# Altium schematic sheet Sheet4.SchDoc
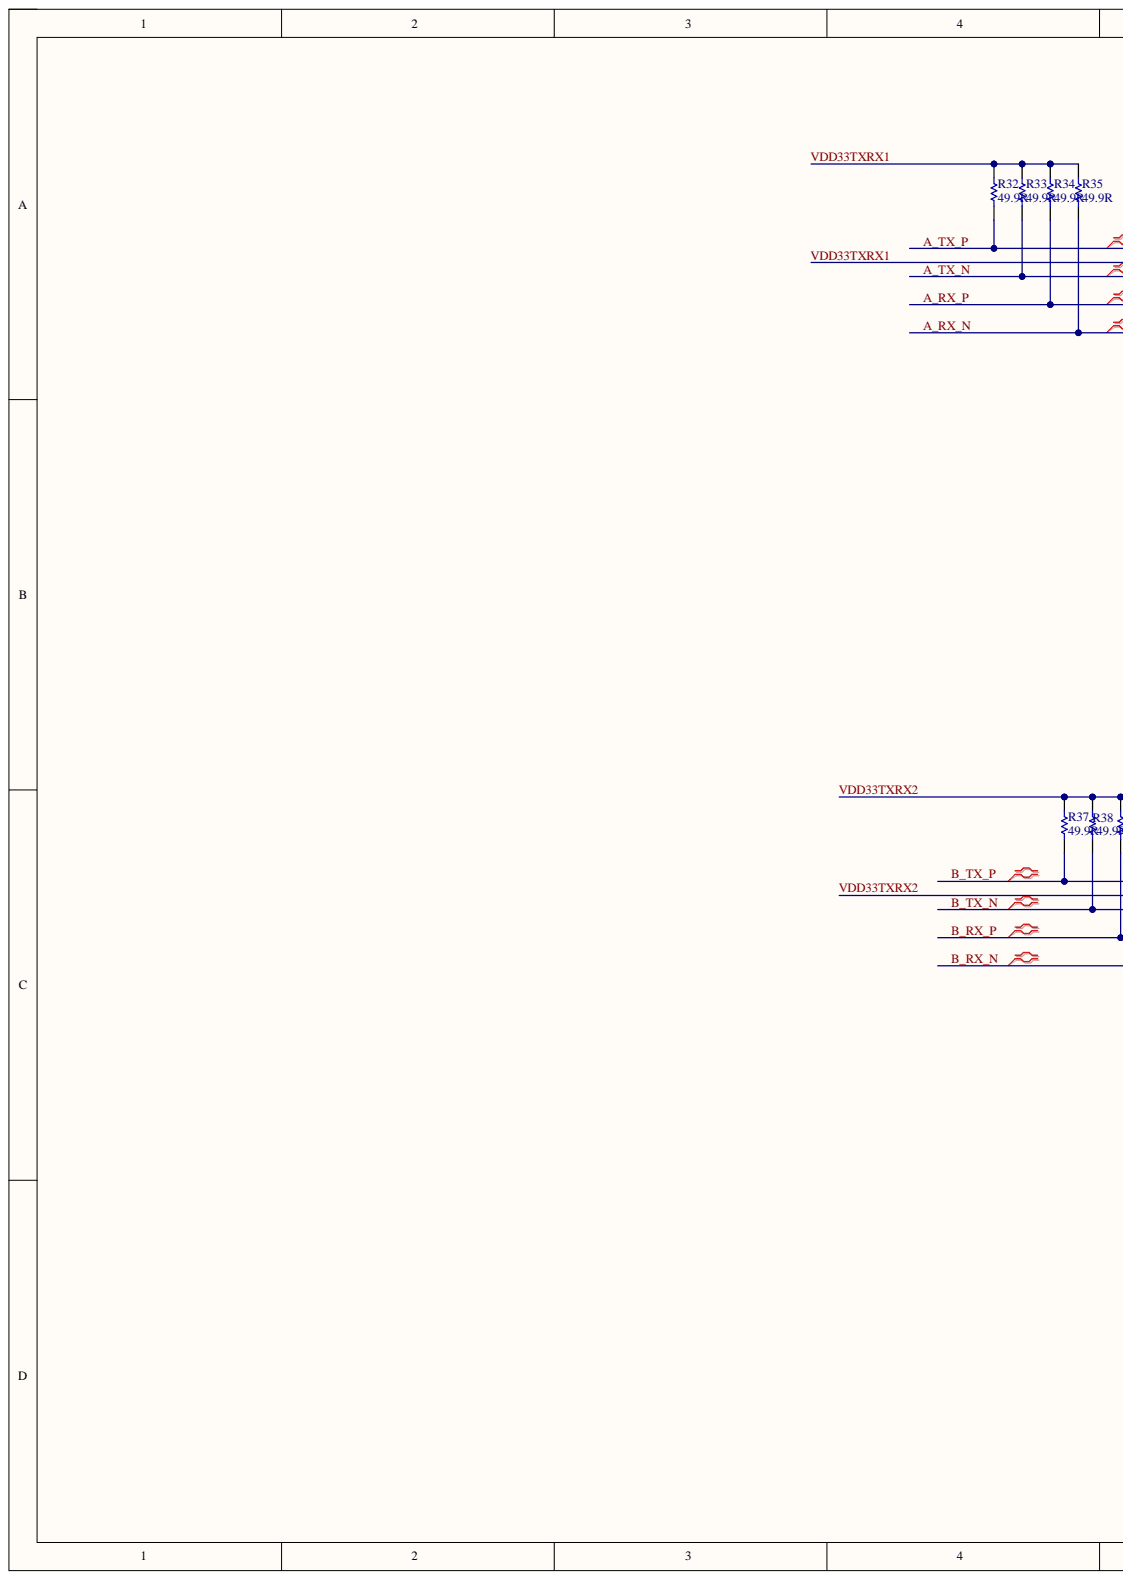
[diagram p0: Sheet4.SchDoc, left]
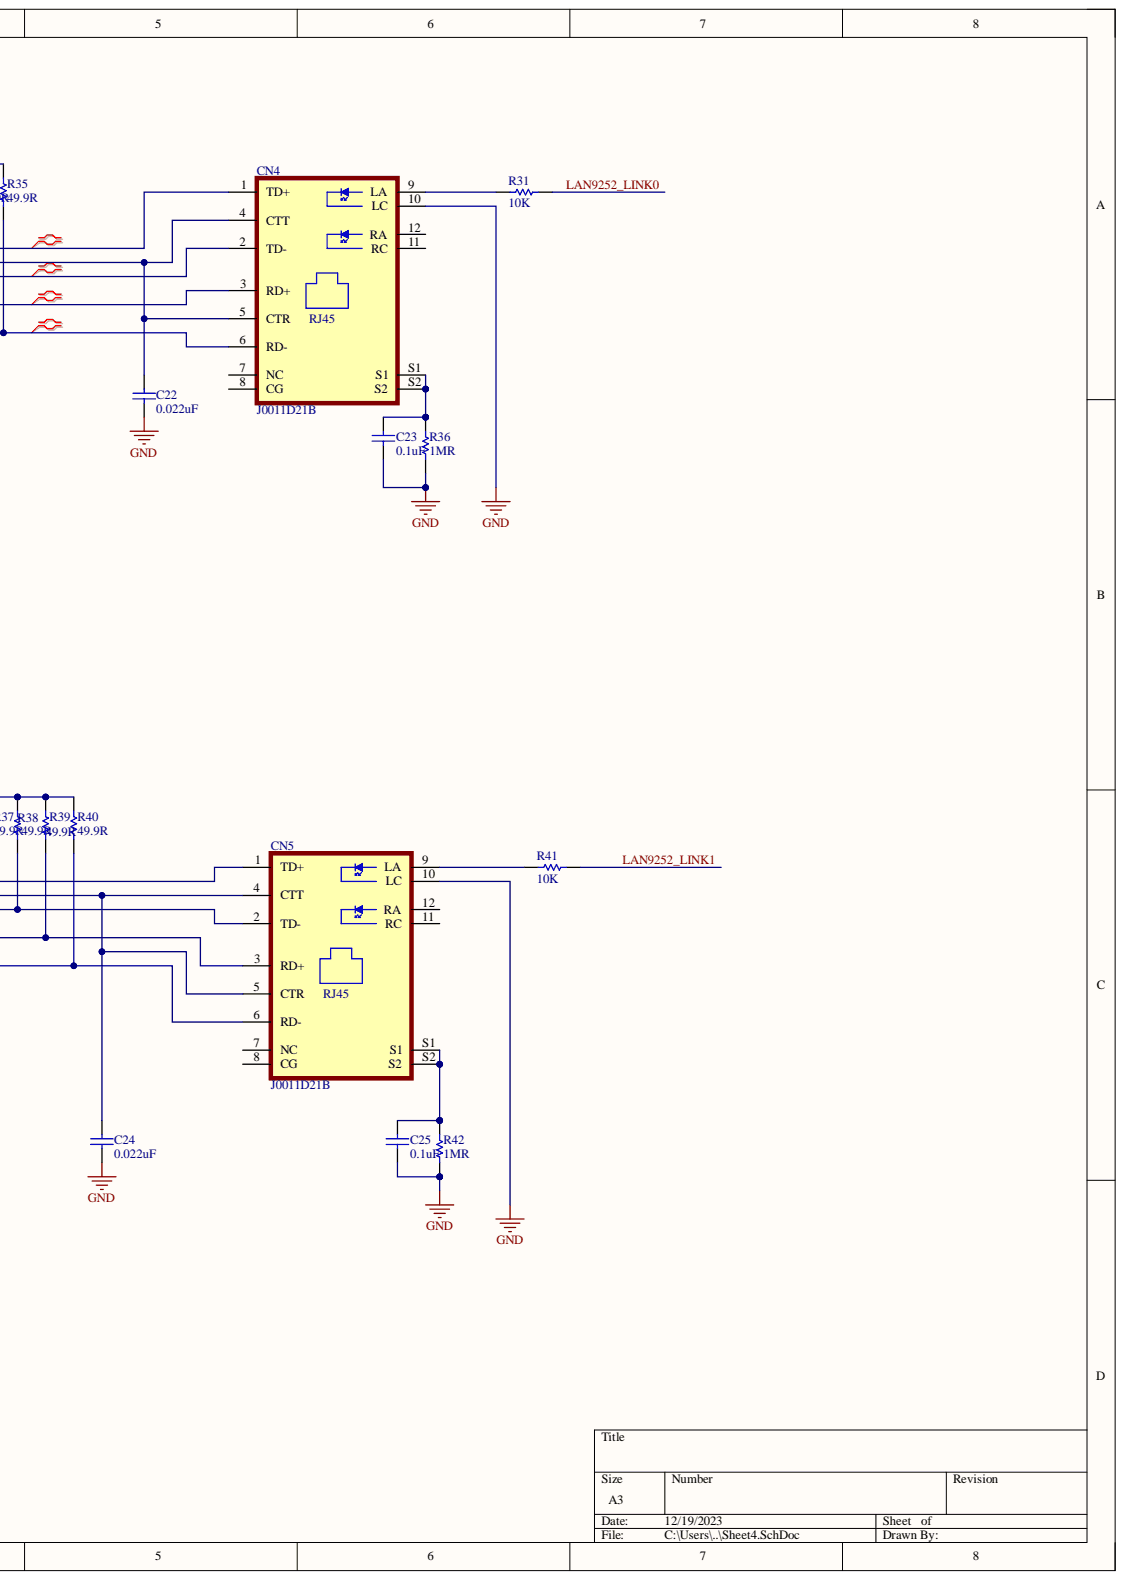
[diagram p1: Sheet4.SchDoc, right]

## Parts
C22  value 0.022uF  [Capacitor_1608]  (hidden comment: Condenser_1608)
C23  value 0.1uF  [Capacitor_2012]  (hidden comment: Condenser_2012)
C24  value 0.022uF  [Capacitor_1608]  (hidden comment: Condenser_1608)
C25  value 0.1uF  [Capacitor_2012]  (hidden comment: Condenser_2012)
CN4  J0011D21B  [J0011D21B]
CN5  J0011D21B  [J0011D21B]
R31  value 10K  [Resistance_1608]  (hidden comment: Resista_1608)
R32  value 49.9R  [Resistance_1608]  (hidden comment: Resista_1608)
R33  value 49.9R  [Resistance_1608]  (hidden comment: Resista_1608)
R34  value 49.9R  [Resistance_1608]  (hidden comment: Resista_1608)
R35  value 49.9R  [Resistance_1608]  (hidden comment: Resista_1608)
R36  value 1MR  [Resistance_2012]  (hidden comment: Resista_2012)
R37  value 49.9R  [Resistance_1608]  (hidden comment: Resista_1608)
R38  value 49.9R  [Resistance_1608]  (hidden comment: Resista_1608)
R39  value 49.9R  [Resistance_1608]  (hidden comment: Resista_1608)
R40  value 49.9R  [Resistance_1608]  (hidden comment: Resista_1608)
R41  value 10K  [Resistance_1608]  (hidden comment: Resista_1608)
R42  value 1MR  [Resistance_2012]  (hidden comment: Resista_2012)

## Nets
GND: C22.1 C23.1 C24.1 C25.1 CN4.10(LC) CN5.10(LC) R36.1 R42.1
A_RX_N: CN4.6(RD-) R35.2
A_RX_P: CN4.3(RD+) R34.2
A_TX_N: CN4.2(TD-) R33.2
A_TX_P: CN4.1(TD+) R32.2
B_RX_N: CN5.6(RD-) R40.2
B_RX_P: CN5.3(RD+) R39.2
B_TX_N: CN5.2(TD-) R38.2
B_TX_P: CN5.1(TD+) R37.2
LAN9252_LINK0: R31.2
LAN9252_LINK1: R41.2
VDD33TXRX1: C22.2 CN4.4(CTT) CN4.5(CTR) R32.1 R33.1 R34.1 R35.1
VDD33TXRX2: C24.2 CN5.4(CTT) CN5.5(CTR) R37.1 R38.1 R39.1 R40.1
NetC23_2: C23.2 CN4.S1 CN4.S2 R36.2
NetC25_2: C25.2 CN5.S1 CN5.S2 R42.2
NetCN4_9: CN4.9(LA) R31.1
NetCN5_9: CN5.9(LA) R41.1

Not connected: CN4 7(NC) 8(CG) 11(RC) 12(RA); CN5 7(NC) 8(CG) 11(RC) 12(RA)

## Library data of the parts
C22 C24 = Condenser_1608: Component Kind: Standard; Library Name: MyLaibrary.SchLib
C23 C25 = Condenser_2012: Component Kind: Standard; Library Name: MyLaibrary.SchLib
CN4 CN5 = J0011D21B: Component Kind: Standard; Library Name: MyLaibrary.SchLib
R31-R35 R37-R41 = Resista_1608: Component Kind: Standard; Library Name: MyLaibrary.SchLib
R36 R42 = Resista_2012: Component Kind: Standard; Library Name: MyLaibrary.SchLib
